# Altium schematic sheet Connectors.Schdoc
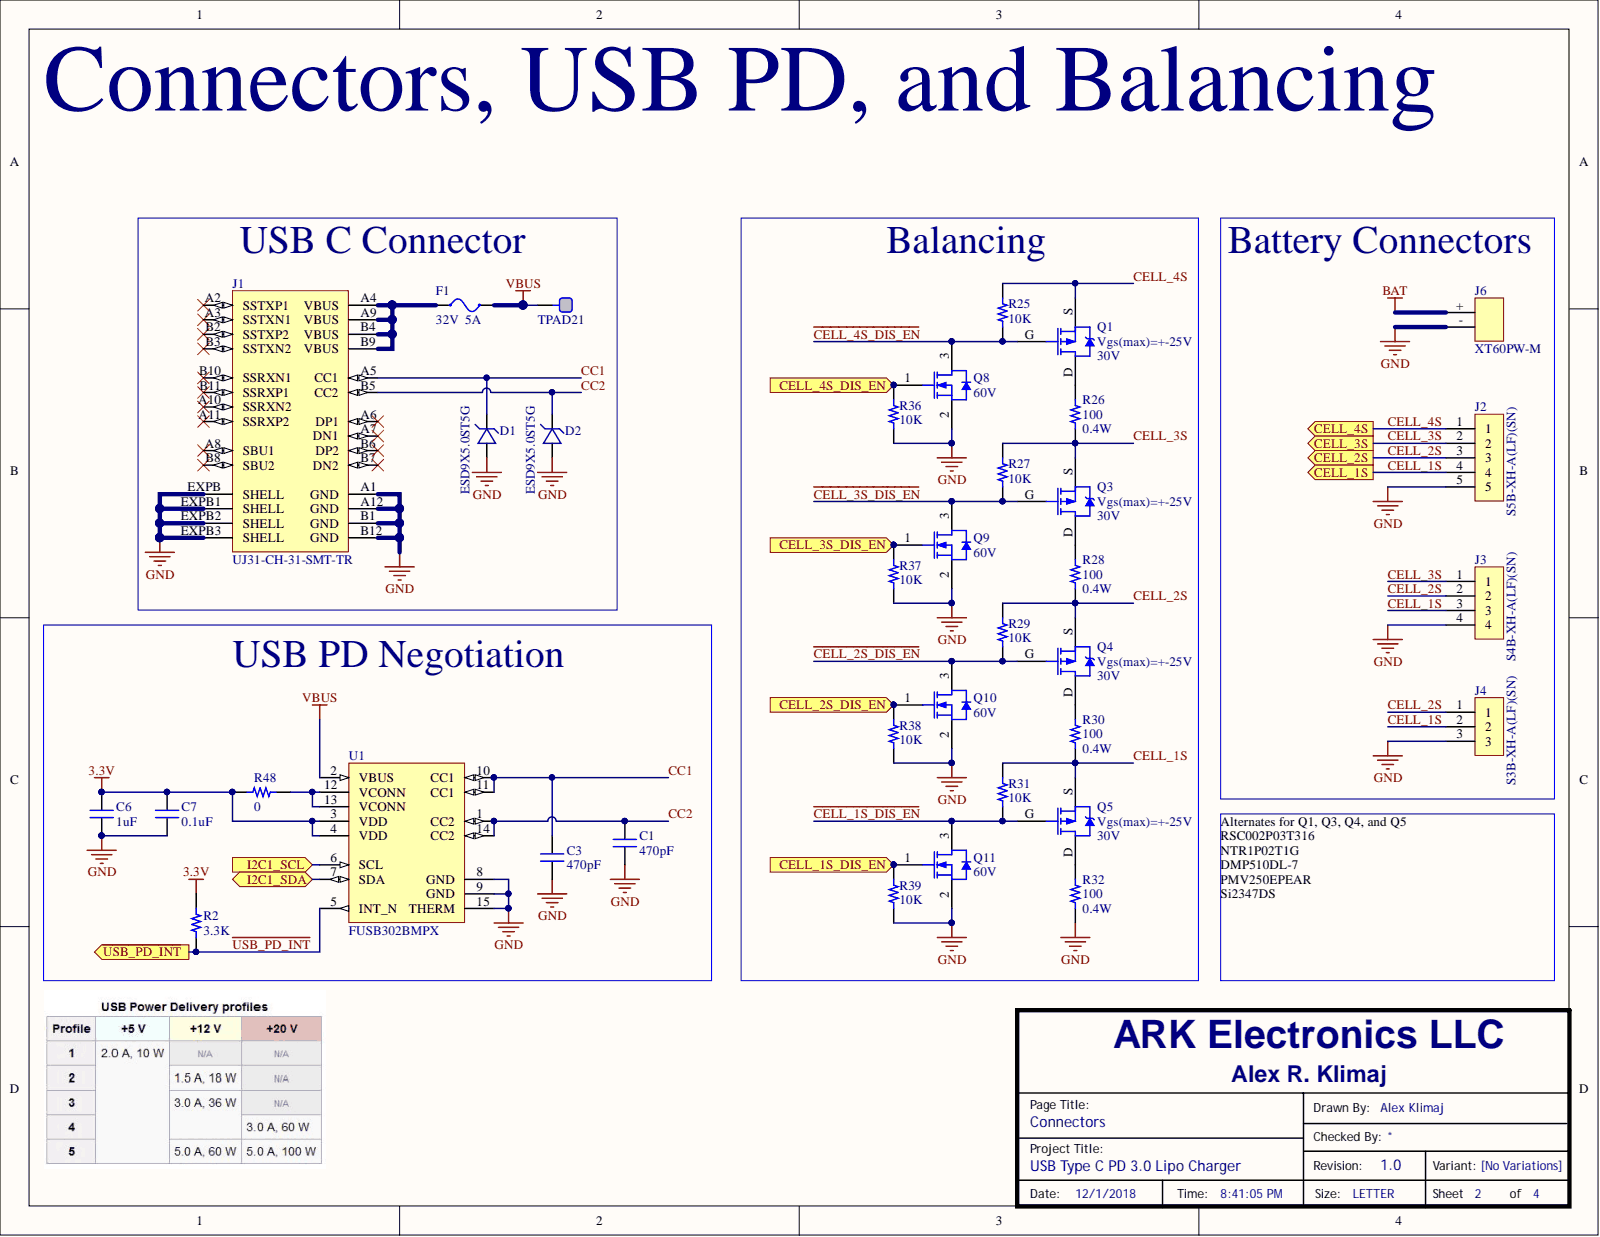
[diagram p0: Connectors.Schdoc]

## Parts
C1  470pF  [CAPC0805(2012)155_N]
C3  470pF  [CAPC0805(2012)155_N]
C6  1uF  [CAPC0805(2012)155_N]
C7  0.1uF  [CAPC0805(2012)155_N]
D1  ESD9X5.0ST5G  [SOD-923]
D2  ESD9X5.0ST5G  [SOD-923]
F1  Current_Rating: 5A  Voltage_Rating: 32V  [Fuse 0603]  (hidden comment: MFU0603FF05000P100)
J1  UJ31-CH-31-SMT-TR  [CUI_UJ31-CH-31-SMT-TR_UJ31-CH-31-SMT-TR(Primary)]
J2  value S5B-XH-A(LF)(SN)  Mfr Part Number: S5B-XH-A(LF)(SN)  [S5B-XH-A]  (hidden comment: S5B-XH-A 5 Pin Header)
J3  S4B-XH-A(LF)(SN)  [S4B-XH-A]
J4  S3B-XH-A(LF)(SN)  [S3B-XH-A]
J6  XT60PW-M  [XT60PW-M]
Q1  Vgs(max)=+-25V  Voltage_Rating: 30V  [Diode SOT23 PMOS]
Q3  Vgs(max)=+-25V  Voltage_Rating: 30V  [Diode SOT23 PMOS]
Q4  Vgs(max)=+-25V  Voltage_Rating: 30V  [Diode SOT23 PMOS]
Q5  Vgs(max)=+-25V  Voltage_Rating: 30V  [Diode SOT23 PMOS]
Q8  Voltage_Rating: 60V  [TO-236AB (SOT23)]  (hidden comment: NX7002AK,215)
Q9  Voltage_Rating: 60V  [TO-236AB (SOT23)]  (hidden comment: NX7002AK,215)
Q10  Voltage_Rating: 60V  [TO-236AB (SOT23)]  (hidden comment: NX7002AK,215)
Q11  Voltage_Rating: 60V  [TO-236AB (SOT23)]  (hidden comment: NX7002AK,215)
R2  3.3K  [RESC0805(2012)_L]
R25  10K  [RESC0805(2012)_L]
R26  100  Power_Rating: 0.4W  [RESC0805(2012)_L]
R27  10K  [RESC0805(2012)_L]
R28  100  Power_Rating: 0.4W  [RESC0805(2012)_L]
R29  10K  [RESC0805(2012)_L]
R30  100  Power_Rating: 0.4W  [RESC0805(2012)_L]
R31  10K  [RESC0805(2012)_L]
R32  100  Power_Rating: 0.4W  [RESC0805(2012)_L]
R36  10K  [RESC0805(2012)_L]
R37  10K  [RESC0805(2012)_L]
R38  10K  [RESC0805(2012)_L]
R39  10K  [RESC0805(2012)_L]
R48  0  [RESC0805(2012)_L]
TPAD21  TEST_PAD  [TEST_POINT]  (value not drawn)  (no BOM)
U1  FUSB302BMPX  [WQFN14 2.5x2.5, 0.5P]

## Ports
CELL_1S, CELL_1S_DIS_EN, CELL_2S, CELL_2S_DIS_EN, CELL_3S, CELL_3S_DIS_EN, CELL_4S, CELL_4S_DIS_EN, I2C1_SCL, I2C1_SDA, ~{USB_PD_INT}

## Nets
3.3V: C6.2 C7.2 R2.2 R48.1 U1.3(VDD) U1.4(VDD)
BAT: J6.+
GND: C1.1 C3.1 C6.1 C7.1 D1.2 D2.2 J1.A1(GND) J1.A12(GND) J1.B1(GND) J1.B12(GND) J1.EXPB(SHELL) J1.EXPB1(SHELL) J1.EXPB2(SHELL) J1.EXPB3(SHELL) J2.5 J3.4 J4.3 J6.- Q8.2 Q9.2 Q10.2 Q11.2 R32.2 R36.2 R37.2 R38.2 R39.2 U1.8(GND) U1.9(GND) U1.15(THERM)
VBUS: F1.1 TPAD21.1 U1.2(VBUS)
~{CELL_1S_DIS_EN}: Q5.G Q11.3 R31.2
~{CELL_2S_DIS_EN}: Q4.G Q10.3 R29.2
~{CELL_3S_DIS_EN}: Q3.G Q9.3 R27.2
~{CELL_4S_DIS_EN}: Q1.G Q8.3 R25.2
CC1: C3.2 D1.1 J1.A5(CC1) U1.10(CC1) U1.11(CC1)
CC2: C1.2 D2.1 J1.B5(CC2) U1.1(CC2) U1.14(CC2)
CELL_1S: J2.4 J3.3 J4.2 Q5.S R30.2 R31.1 ; port CELL_1S
CELL_1S_DIS_EN: Q11.1 R39.1 ; port CELL_1S_DIS_EN
CELL_2S: J2.3 J3.2 J4.1 Q4.S R28.2 R29.1 ; port CELL_2S
CELL_2S_DIS_EN: Q10.1 R38.1 ; port CELL_2S_DIS_EN
CELL_3S: J2.2 J3.1 Q3.S R26.2 R27.1 ; port CELL_3S
CELL_3S_DIS_EN: Q9.1 R37.1 ; port CELL_3S_DIS_EN
CELL_4S: J2.1 Q1.S R25.1 ; port CELL_4S
CELL_4S_DIS_EN: Q8.1 R36.1 ; port CELL_4S_DIS_EN
I2C1_SCL: U1.6(SCL) ; port I2C1_SCL
I2C1_SDA: U1.7(SDA) ; port I2C1_SDA
~{USB_PD_INT}: R2.1 U1.5(INT_N) ; port ~{USB_PD_INT}
NetF1_2: F1.2 J1.A4(VBUS) J1.A9(VBUS) J1.B4(VBUS) J1.B9(VBUS)
NetQ1_D: Q1.D R26.1
NetQ3_D: Q3.D R28.1
NetQ4_D: Q4.D R30.1
NetQ5_D: Q5.D R32.1
NetR48_2: R48.2 U1.12(VCONN) U1.13(VCONN)

Not connected: J1 A2(SSTXP1) A3(SSTXN1) A6(DP1) A7(DN1) A8(SBU1) A10(SSRXN2) A11(SSRXP2) B2(SSTXP2) B3(SSTXN2) B6(DP2) B7(DN2) B8(SBU2) B10(SSRXN1) B11(SSRXP1)

## Library data of the parts
C1 C3 = 30241: SMT Capacitor; Manufacturer: Wurth Electronics Inc.; Mfr Part Description: CAP CER 470pF ±10% 25V X7R 0805 (2012 Metric); Mfr Part Number: 885012207058; Database Table Name: Parts; Dielectric: X7R; Tolerance: ±10%; Updated By: aklimaj; Voltage_Rating: 25V; Component Kind: Standard; ComponentLink1Description: Datasheet; ComponentLink1URL: https://katalog.we-online.de/pbs/datasheet/885012207058.pdf; ComponentLink2Description: Supplier Part Details; ComponentLink2URL: https://www.digikey.com/product-detail/en/wurth-electronics-inc/885012207058/732-12278-1-ND/9346298; Created_Date: 2018/11/03 20:44:15; ID: 30241; Library Name: Parts.DbLib; LifeCycle: New; Package_Case: 0805 (2012 Metric); Part_Type: Capacitor; RoHS: Yes; Supplier: Digi-Key; Supplier Part Number: 732-12278-1-ND; Temperature Range: -55C to +125C; Updated_Date: 2018/11/03 20:44:34; Value: 470pF; Created By: aklimaj
C6 = 30222: SMT Capacitor; Manufacturer: Samsung Electro-Mechanics; Mfr Part Description: CAP CER 1uF ±10% 50V X7R 0805 (2012 Metric); Mfr Part Number: CL21B105KBFNNNG; Database Table Name: Parts; Dielectric: X7R; Tolerance: ±10%; Updated By: aklimaj; Voltage_Rating: 50V; Component Kind: Standard; ComponentLink1Description: Datasheet; ComponentLink1URL: http://www.samsungsem.com/kr/support/product-search/mlcc/CL21B105KBFNNNG.jsp; ComponentLink2Description: Supplier Part Details; ComponentLink2URL: https://www.digikey.com/product-detail/en/samsung-electro-mechanics/CL21B105KBFNNNG/1276-6470-1-ND/5958098; Created_Date: 2018/10/14 19:30:56; ID: 30222; Library Name: Parts.DbLib; LifeCycle: New; Package_Case: 0805 (2012 Metric); Part_Type: Capacitor; RoHS: Yes; Supplier: Digi-Key; Supplier Part Number: 1276-6470-1-ND; Temperature Range: -55C to +125C; Updated_Date: 2018/10/19 21:37:42; Value: 1uF; Created By: aklimaj
C7 = 30221: SMT Capacitor; Manufacturer: Samsung Electro-Mechanics; Mfr Part Description: CAP CER 0.1uF ±10% 100V X7R 0805 (2012 Metric); Mfr Part Number: CL21B104KCFNNNE; Database Table Name: Parts; Dielectric: X7R; Tolerance: ±10%; Updated By: aklimaj; Voltage_Rating: 100V; Component Kind: Standard; ComponentLink1Description: Datasheet; ComponentLink1URL: http://www.samsungsem.com/kr/support/product-search/mlcc/CL21B104KCFNNNE.jsp; ComponentLink2Description: Supplier Part Details; ComponentLink2URL: https://www.digikey.com/product-detail/en/samsung-electro-mechanics/CL21B104KCFNNNE/1276-6840-1-ND/5961699; Created_Date: 2018/10/14 19:28:20; ID: 30221; Library Name: Parts.DbLib; LifeCycle: New; Package_Case: 0805 (2012 Metric); Part_Type: Capacitor; RoHS: Yes; Supplier: Digi-Key; Supplier Part Number: 1276-6840-1-ND; Temperature Range: -55C to +125C; Updated_Date: 2018/10/19 21:37:43; Value: 0.1uF; Created By: aklimaj
D1 D2 = 30239: TVS Diode; Manufacturer: ON Semiconductor; Mfr Part Description: TVS DIODE 5V 12.3V SOD923; Part_Type: Protection; Current_Rating: 8.7A (8/20µs); Database Table Name: Parts; Updated By: aklimaj; Component Kind: Standard; ComponentLink1Description: Datasheet; ComponentLink1URL: http://www.onsemi.com/pub/Collateral/ESD9X3.3ST5G-D.PDF; ComponentLink2Description: Supplier Part Details; ComponentLink2URL: https://www.digikey.com/product-detail/en/on-semiconductor/ESD9X5.0ST5G/ESD9X5.0ST5GOSCT-ND/2120624; Created_Date: 2018/11/03 11:31:29; ID: 30239; Library Name: Parts.DbLib; LifeCycle: New; Mfr Part Number: ESD9X5.0ST5G; Package_Case: SOD-923; RoHS: Yes; Supplier: Digi-Key; Supplier Part Number: ESD9X5.0ST5GOSCT-ND; Temperature Range: -55C to +150C (TJ); Updated_Date: 2018/11/03 11:39:36; Value: ESD9X5.0ST5G; Created By: aklimaj
F1 = 30231: FUSE; Manufacturer: Vishay Beyschlag; Mfr Part Description: FUSE BOARD MOUNT 5A 32VDC 0603; Part_Type: Protection; Database Table Name: Parts; Updated By: aklimaj; Component Kind: Standard; ComponentLink1Description: Datasheet; ComponentLink1URL: http://www.vishay.com/docs/28747/mfuserie.pdf; ComponentLink2Description: Supplier Part Details; ComponentLink2URL: https://www.digikey.com/product-detail/en/vishay-beyschlag/MFU0603FF05000P100/MFU06035.00CT-ND/1878995; Created_Date: 2018/10/26 21:34:10; ID: 30231; Library Name: Parts.DbLib; LifeCycle: New; Mfr Part Number: MFU0603FF05000P100; Package_Case: 0603 (1608 Metric); RoHS: Yes; Supplier: Digi-Key; Supplier Part Number: MFU06035.00CT-ND; Temperature Range: -55C to +125C; Updated_Date: 2018/10/26 21:42:25; Value: MFU0603FF05000P100; Created By: aklimaj
J1 = 30219: Manufacturer: CUI Inc.; Mfr Part Description: USB JACK 3.1, C TYPE, 24 PIN, HO; Part_Type: Connector; Current_Rating: 0.25A, 1.25A, 5A, 6.25A; Database Table Name: Parts; Updated By: aklimaj; Voltage_Rating: 20V; Component Kind: Standard; ComponentLink1Description: Datasheet; ComponentLink1URL: https://www.cui.com/product/resource/digikeypdf/uj31-ch-smt.pdf; ComponentLink2Description: Supplier Part Details; ComponentLink2URL: https://www.digikey.com/product-detail/en/cui-inc/UJ31-CH-31-SMT-TR/102-4485-1-ND/8024065; Created_Date: 2018/10/14 19:06:33; ID: 30219; Library Name: Parts.DbLib; LifeCycle: New; Mfr Part Number: UJ31-CH-31-SMT-TR; RoHS: Yes; Supplier: Digi-Key; Supplier Part Number: 102-4485-1-ND; Temperature Range: -30C to +85C; Updated_Date: 2018/10/19 21:37:45; Value: UJ31-CH-31-SMT-TR; Created By: aklimaj
J2 = 30040: Manufacturer: JST Sales America Inc.; Mfr Part Description: CONN HEADER XH SIDE 5POS 2.5MM; Part_Type: Connector; Teal Part Number: 20358; Current_Rating: 3A; Database Table Name: Parts; Updated By: aklimaj; Voltage_Rating: 250V; Component Kind: Standard; ComponentLink1Description: Datasheet; ComponentLink1URL: http://www.jst-mfg.com/product/pdf/eng/eXH.pdf; ComponentLink2Description: Supplier Part Details; ComponentLink2URL: https://www.digikey.com/products/en?keywords=S5B-XH-A; Created_Date: 2017/08/01 14:44:19; Description: S5B-XH-A 5 Pin Header; ID: 30040; Library Name: Parts.DbLib; LifeCycle: New; RoHS: Yes; Supplier: Digi-Key; Supplier Part Number: 455-2242-ND; Temperature Range: -25C to +85C; Updated_Date: 2017/08/01 14:44:41; Created By: aklimaj
J3 = 30228: Manufacturer: JST Sales America Inc.; Mfr Part Description: CONN HEADER XH SIDE 4POS 2.5MM; Part_Type: Connector; Current_Rating: 3A; Database Table Name: Parts; Updated By: aklimaj; Voltage_Rating: 250V; Component Kind: Standard; ComponentLink1Description: Datasheet; ComponentLink1URL: http://www.jst-mfg.com/product/pdf/eng/eXH.pdf; ComponentLink2Description: Supplier Part Details; ComponentLink2URL: https://www.digikey.com/product-detail/en/jst-sales-america-inc/S4B-XH-A-LF-SN/455-2243-ND/1651041; Created_Date: 2018/10/24 21:15:23; ID: 30228; Library Name: Parts.DbLib; LifeCycle: New; Mfr Part Number: S4B-XH-A(LF)(SN); RoHS: Yes; Supplier: Digi-Key; Supplier Part Number: 455-2243-ND; Temperature Range: -25C to +85C; Updated_Date: 2018/10/24 21:23:10; Value: S4B-XH-A(LF)(SN); Created By: aklimaj
J4 = 30229: Manufacturer: JST Sales America Inc.; Mfr Part Description: CONN HEADER XH SIDE 3POS 2.5MM; Part_Type: Connector; Current_Rating: 3A; Database Table Name: Parts; Updated By: aklimaj; Voltage_Rating: 250V; Component Kind: Standard; ComponentLink1Description: Datasheet; ComponentLink1URL: http://www.jst-mfg.com/product/pdf/eng/eXH.pdf; ComponentLink2Description: Supplier Part Details; ComponentLink2URL: https://www.digikey.com/product-detail/en/jst-sales-america-inc/S3B-XH-A-LF-SN/455-2250-ND/1651048; Created_Date: 2018/10/24 21:25:23; ID: 30229; Library Name: Parts.DbLib; LifeCycle: New; Mfr Part Number: S3B-XH-A(LF)(SN); RoHS: Yes; Supplier: Digi-Key; Supplier Part Number: 455-2250-ND; Temperature Range: -25C to +85C; Updated_Date: 2018/10/24 21:29:57; Value: S3B-XH-A(LF)(SN); Created By: aklimaj
J6 = 30265: Manufacturer: AMASS; Mfr Part Description: Socket; DC supply; XT60; male; PIN:2; on PCBs; THT; Colour: yellow; Current_Rating: 45A; Database Table Name: Parts; Updated By: aklimaj; Voltage_Rating: 500V; Component Kind: Standard; ComponentLink1Description: Datasheet; ComponentLink1URL: https://www.tme.eu/en/Document/9b8d0c5eb7094295f3d3112c214d3ade/XT60PW%20SPEC.pdf; ComponentLink2Description: Supplier Part Details; ComponentLink2URL: https://www.tme.eu/en/details/xt60pw-m/dc-power-connectors/amass/; Created_Date: 2018/11/08 10:32:01; ID: 30265; Library Name: Parts.DbLib; LifeCycle: New; Mfr Part Number: XT60PW-M; RoHS: Yes; Supplier: tme.eu; Supplier Part Number: XT60PW-M; Temperature Range: -20C to 120C; Updated_Date: 2018/11/08 11:11:39; Value: XT60PW-M; Created By: aklimaj
Q1 Q3-Q5 = 30266: Manufacturer: Diodes Incorporated; Mfr Part Description: MOSFET P-CH 30V 4.3A SOT23; Mfr Part Number: DMP3056L-7; Part_Type: Discrete; Current_Rating: 4.3A (Ta); Database Table Name: Parts; Updated By: aklimaj; Value: DMP3056L-7; Component Kind: Standard; ComponentLink1Description: Datasheet; ComponentLink1URL: https://www.diodes.com/assets/Datasheets/DMP3056L.pdf; ComponentLink2Description: Supplier Part Details; ComponentLink2URL: https://www.digikey.com/product-detail/en/diodes-incorporated/DMP3056L-7/DMP3056L-7DICT-ND/5126873; Created_Date: 2018/11/08 12:42:57; ID: 30266; Library Name: Parts.DbLib; LifeCycle: New; Package_Case: TO-236-3, SC-59, SOT-23-3; RoHS: Yes; Supplier: Digi-Key; Supplier Part Number: DMP3056L-7DICT-ND; Temperature Range: -55C to +150C (TJ); Updated_Date: 2018/11/08 12:54:41; Created By: aklimaj
Q8-Q11 = 30247: Manufacturer: Nexperia USA Inc.; Mfr Part Description: MOSFET N-CH 60V TO-236AB; Part_Type: Discrete; Current_Rating: 190mA (Ta); Database Table Name: Parts; Updated By: aklimaj; Component Kind: Standard; ComponentLink1Description: Datasheet; ComponentLink1URL: https://assets.nexperia.com/documents/data-sheet/NX7002AK.pdf; ComponentLink2Description: Supplier Part Details; ComponentLink2URL: https://www.digikey.com/product-detail/en/nexperia-usa-inc/NX7002AK215/1727-1289-1-ND/4386095; Created_Date: 2018/11/03 22:07:14; ID: 30247; Library Name: Parts.DbLib; LifeCycle: New; Mfr Part Number: NX7002AK,215; Package_Case: TO-236-3, SC-59, SOT-23-3; RoHS: Yes; Supplier: Digi-Key; Supplier Part Number: 1727-1289-1-ND; Temperature Range: -55C to +150C (TJ); Updated_Date: 2018/11/03 22:14:37; Value: NX7002AK,215; Created By: aklimaj
R2 = 30235: SMT Resistor; Manufacturer: Stackpole Electronics Inc.; Mfr Part Description: RES 3.3K Ohm ±1% 1/8W 0805 (2012 Metric); Mfr Part Number: RMCF0805FT3K30; Database Table Name: Parts; Power_Rating: 0.125W, 1/8W; Temperature Coeff: ±100ppm/degC; Tolerance: ±1%; Updated By: aklimaj; Component Kind: Standard; ComponentLink1Description: Datasheet; ComponentLink1URL: https://www.seielect.com/Catalog/SEI-RMCF_RMCP.pdf; ComponentLink2Description: Supplier Part Details; ComponentLink2URL: https://www.digikey.com/product-detail/en/stackpole-electronics-inc/RMCF0805FT3K30/RMCF0805FT3K30CT-ND/1942399; Created_Date: 2018/11/02 19:30:31; ID: 30235; Library Name: Parts.DbLib; LifeCycle: New; Package_Case: 0805 (2012 Metric); Part_Type: Resistor; RoHS: Yes; Supplier: Digi-Key; Supplier Part Number: RMCF0805FT3K30CT-ND; Temperature Range: -55C to +155C; Updated_Date: 2018/11/02 19:31:08; Value: 3.3K; Created By: aklimaj
R25 R27 R29 R31 R36-R39 = 30227: SMT Resistor; Manufacturer: Bourns Inc.; Mfr Part Description: RES 10K Ohm ±1% 1/8W 0805 (2012 Metric); Mfr Part Number: CR0805-FX-1002ELF; Database Table Name: Parts; Power_Rating: 0.125W, 1/8W; Temperature Coeff: ±100ppm/degC; Tolerance: ±1%; Updated By: aklimaj; Component Kind: Standard; ComponentLink1Description: Datasheet; ComponentLink1URL: https://www.bourns.com/docs/Product-Datasheets/CHPREZTR.pdf; ComponentLink2Description: Supplier Part Details; ComponentLink2URL: https://www.digikey.com/product-detail/en/bourns-inc/CR0805-FX-1002ELF/CR0805-FX-1002ELFCT-ND/3592928; Created_Date: 2018/10/16 22:16:46; ID: 30227; Library Name: Parts.DbLib; LifeCycle: New; Package_Case: 0805 (2012 Metric); Part_Type: Resistor; RoHS: Yes; Supplier: Digi-Key; Supplier Part Number: CR0805-FX-1002ELFCT-ND; Temperature Range: -55C to +155C; Updated_Date: 2018/10/19 21:37:37; Value: 10K; Created By: aklimaj
R26 R28 R30 R32 = 30246: SMT Resistor; Manufacturer: Rohm Semiconductor; Mfr Part Description: RES 100 Ohm ±5% 0.4W, 2/5W 0805 (2012 Metric); Mfr Part Number: ESR10EZPJ101; Database Table Name: Parts; Temperature Coeff: ±200ppm/degC; Tolerance: ±5%; Updated By: aklimaj; Component Kind: Standard; ComponentLink1Description: Datasheet; ComponentLink1URL: https://www.rohm.com/datasheet/ESR01MZPF/esr-e; ComponentLink2Description: Supplier Part Details; ComponentLink2URL: https://www.digikey.com/product-detail/en/rohm-semiconductor/ESR10EZPJ101/RHM100KCT-ND/1762950; Created_Date: 2018/11/03 21:46:05; ID: 30246; Library Name: Parts.DbLib; LifeCycle: New; Package_Case: 0805 (2012 Metric); Part_Type: Resistor; RoHS: Yes; Supplier: Digi-Key; Supplier Part Number: RHM100KCT-ND; Temperature Range: -55C to +155C; Updated_Date: 2018/11/03 21:47:27; Value: 100; Created By: aklimaj
R48 = 30238: SMT Resistor; Manufacturer: Bourns Inc.; Mfr Part Description: RES 0 Ohm Jumper 1/8W 0805 (2012 Metric); Mfr Part Number: CR0805-J/-000ELF; Current_Rating: 2A; Database Table Name: Parts; Power_Rating: 0.125W, 1/8W; Tolerance: Jumper; Updated By: aklimaj; Voltage_Rating: 150V; Component Kind: Standard; ComponentLink1Description: Datasheet; ComponentLink1URL: https://www.bourns.com/docs/Product-Datasheets/CHPREZTR.pdf; ComponentLink2Description: Supplier Part Details; ComponentLink2URL: https://www.digikey.com/product-detail/en/bourns-inc/CR0805-J-000ELF/CR0805-J-000ELFCT-ND/3592913; Created_Date: 2018/11/02 22:19:43; ID: 30238; Library Name: Parts.DbLib; LifeCycle: New; Package_Case: 0805 (2012 Metric); Part_Type: Resistor; RoHS: Yes; Supplier: Digi-Key; Supplier Part Number: CR0805-J/-000ELFCT-ND; Temperature Range: -55C to +155C; Updated_Date: 2018/11/02 22:20:59; Value: 0; Created By: aklimaj
TPAD21 = 30015: Mfr Part Description: Test Point; Database Table Name: Parts; Updated By: aklimaj; ComponentLink1Description: Datasheet; ComponentLink2Description: Supplier Part Details; Created_Date: 2017/07/13 09:26:35; Description: TEST_PAD; ID: 30015; Library Name: Parts.DbLib; LifeCycle: New; Part_Type: Test Point; RoHS: Yes; Updated_Date: 2017/07/13 09:29:11; Created By: aklimaj
U1 = 30218: FUSB302B: Programmable USB Type-C Controller with PD (Default SNK); Manufacturer: ON Semiconductor; Mfr Part Description: IC USB TYPE C CTLR PROGR 14-MLP; Part_Type: IC; Database Table Name: Parts; Updated By: aklimaj; Component Kind: Standard; ComponentLink1Description: Datasheet; ComponentLink1URL: http://www.onsemi.com/pub/Collateral/FUSB302B-D.PDF; ComponentLink2Description: Supplier Part Details; ComponentLink2URL: https://www.digikey.com/product-detail/en/on-semiconductor/FUSB302BMPX/FUSB302BMPXCT-ND/6138870; Created_Date: 2018/10/14 18:59:59; ID: 30218; Library Name: Parts.DbLib; LifeCycle: New; Mfr Part Number: FUSB302BMPX; Package_Case: 14-WFQFN Exposed Pad; RoHS: Yes; Sub_Type: USB; Supplier: Digi-Key; Supplier Part Number: FUSB302BMPXCT-ND; Temperature Range: -40C to +125C; Updated_Date: 2018/10/19 21:37:49; Value: FUSB302BMPX; Created By: aklimaj
